# Altium schematic sheet ServoDrivers.SchDoc
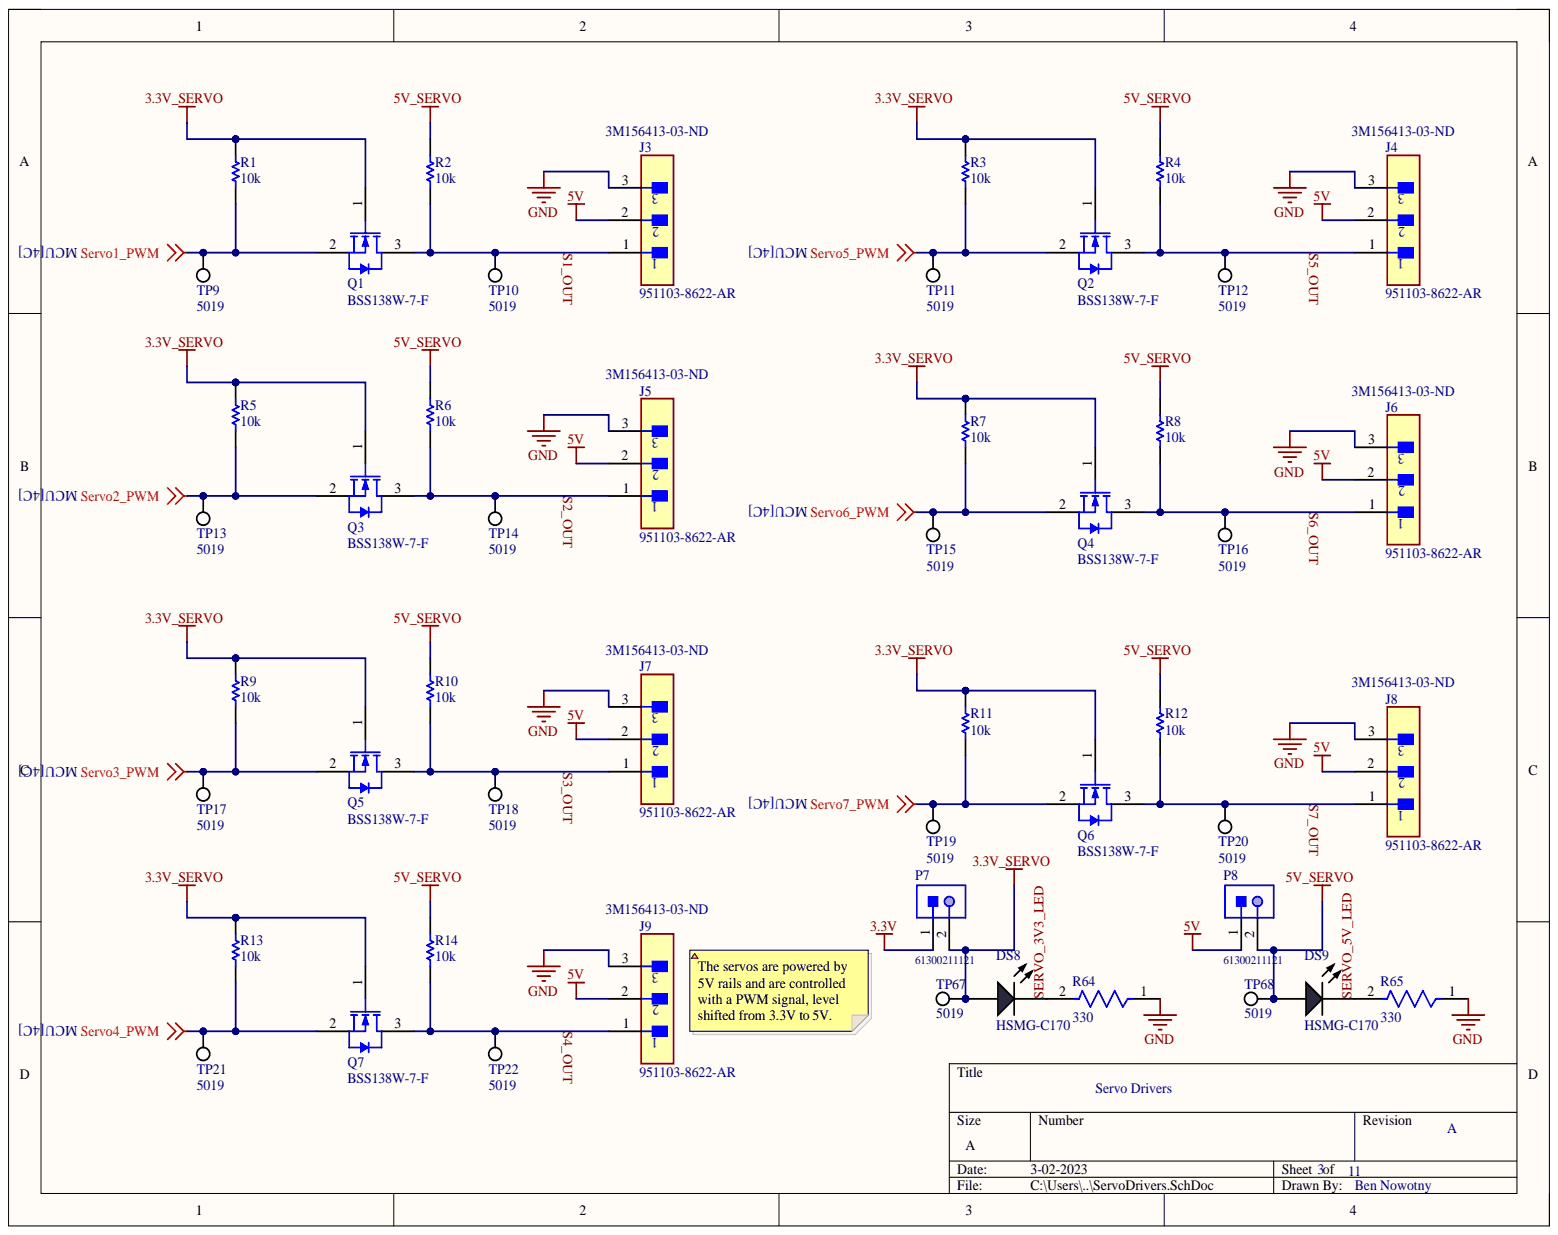
[diagram p0: ServoDrivers.SchDoc]

## Parts
DS8  HSMG-C170  [AVAG-HSMX-C170_V]
DS9  HSMG-C170  [AVAG-HSMX-C170_V]
J3  951103-8622-AR  Supplier Part Number 1: 3M156413-03-ND  [FP-951103-8622-AR-MFG]
J4  951103-8622-AR  Supplier Part Number 1: 3M156413-03-ND  [FP-951103-8622-AR-MFG]
J5  951103-8622-AR  Supplier Part Number 1: 3M156413-03-ND  [FP-951103-8622-AR-MFG]
J6  951103-8622-AR  Supplier Part Number 1: 3M156413-03-ND  [FP-951103-8622-AR-MFG]
J7  951103-8622-AR  Supplier Part Number 1: 3M156413-03-ND  [FP-951103-8622-AR-MFG]
J8  951103-8622-AR  Supplier Part Number 1: 3M156413-03-ND  [FP-951103-8622-AR-MFG]
J9  951103-8622-AR  Supplier Part Number 1: 3M156413-03-ND  [FP-951103-8622-AR-MFG]
P7  61300211121  [61300211121]
P8  61300211121  [61300211121]
Q1  BSS138W-7-F  [DIOD-SOT323-3_V]
Q2  BSS138W-7-F  [DIOD-SOT323-3_V]
Q3  BSS138W-7-F  [DIOD-SOT323-3_V]
Q4  BSS138W-7-F  [DIOD-SOT323-3_V]
Q5  BSS138W-7-F  [DIOD-SOT323-3_V]
Q6  BSS138W-7-F  [DIOD-SOT323-3_V]
Q7  BSS138W-7-F  [DIOD-SOT323-3_V]
R1  10k  [RESC2013X60X35NL10T20]
R2  10k  [RESC2013X60X35NL10T20]
R3  10k  [RESC2013X60X35NL10T20]
R4  10k  [RESC2013X60X35NL10T20]
R5  10k  [RESC2013X60X35NL10T20]
R6  10k  [RESC2013X60X35NL10T20]
R7  10k  [RESC2013X60X35NL10T20]
R8  10k  [RESC2013X60X35NL10T20]
R9  10k  [RESC2013X60X35NL10T20]
R10  10k  [RESC2013X60X35NL10T20]
R11  10k  [RESC2013X60X35NL10T20]
R12  10k  [RESC2013X60X35NL10T20]
R13  10k  [RESC2013X60X35NL10T20]
R14  10k  [RESC2013X60X35NL10T20]
R64  330  [FP-CRCW0805-e3-MFG]
R65  330  [FP-CRCW0805-e3-MFG]
TP9  5019  [KSTN-5019_V]
TP10  5019  [KSTN-5019_V]
TP11  5019  [KSTN-5019_V]
TP12  5019  [KSTN-5019_V]
TP13  5019  [KSTN-5019_V]
TP14  5019  [KSTN-5019_V]
TP15  5019  [KSTN-5019_V]
TP16  5019  [KSTN-5019_V]
TP17  5019  [KSTN-5019_V]
TP18  5019  [KSTN-5019_V]
TP19  5019  [KSTN-5019_V]
TP20  5019  [KSTN-5019_V]
TP21  5019  [KSTN-5019_V]
TP22  5019  [KSTN-5019_V]
TP67  5019  [KSTN-5019_V]
TP68  5019  [KSTN-5019_V]

## Nets
3.3V: P7.1
3.3V_SERVO: DS8.1 P7.2 Q1.1 Q2.1 Q3.1 Q4.1 Q5.1 Q6.1 Q7.1 R1.2 R3.2 R5.2 R7.2 R9.2 R11.2 R13.2 TP67.1
5V: J3.2 J4.2 J5.2 J6.2 J7.2 J8.2 J9.2 P8.1
5V_SERVO: DS9.1 P8.2 R2.2 R4.2 R6.2 R8.2 R10.2 R12.2 R14.2 TP68.1
GND: J3.3 J4.3 J5.3 J6.3 J7.3 J8.3 J9.3 R64.1 R65.1
Servo1_PWM: Q1.2 R1.1 TP9.1
Servo2_PWM: Q3.2 R5.1 TP13.1
Servo3_PWM: Q5.2 R9.1 TP17.1
Servo4_PWM: Q7.2 R13.1 TP21.1
Servo5_PWM: Q2.2 R3.1 TP11.1
Servo6_PWM: Q4.2 R7.1 TP15.1
Servo7_PWM: Q6.2 R11.1 TP19.1
S1_OUT: J3.1 Q1.3 R2.1 TP10.1
S2_OUT: J5.1 Q3.3 R6.1 TP14.1
S3_OUT: J7.1 Q5.3 R10.1 TP18.1
S4_OUT: J9.1 Q7.3 R14.1 TP22.1
S5_OUT: J4.1 Q2.3 R4.1 TP12.1
S6_OUT: J6.1 Q4.3 R8.1 TP16.1
S7_OUT: J8.1 Q6.3 R12.1 TP20.1
SERVO_3V3_LED: DS8.2 R64.2
SERVO_5V_LED: DS9.2 R65.2

## Library data of the parts
DS8 DS9 = CMP-2000-05062-1: Chip LED, Green, 2.2 V, -40 to 85 degC, 2-Pin SMD, Pb-Free, Tape and Reel; Manufacturer: Avago; Bulb Size: 1.25mmx1.4mm; Dominant Wavelength: 572nm; External Depth: 0.8mm; External Length / Height: 2mm; External Width: 1.25mm; Forward Current If: 20mA; Forward Voltage: 2.2V; Forward Voltage VF Max: 2.6V; LED Type: Standard; Lens Shape: Rectangular; Light Area Height: 1mm; Luminous Intensity: 15mcd; Luminous Intensity Min: 4mcd; No. of Pins: 2; Opto Mounting Orientation: Top; Peak Wavelength: 570nm; PIV Max: 5V; SVHC: NoSVHC(16-Jun-2014); Viewing Angle: 170deg; Component Kind: Standard; Datasheet URL: https://datasheet.ciiva.com/29280/180495-da-01-en-chip-led-hsmg-c170-gelb-gruen-29280971.pdf; Datasheet Version: Oct-08; Dominant Wavelength Typ: 572nm; Forward Current If Max: 20mA; LED Colour: Green; LED Mounting: SMD; Library Name: Altium Content Vault; Luminous Intensity Typ: 15mcd; Manufacturer URL: http://www.avagotech.com/; Mounting Type: SMD; MSL: MSL2A-4weeks; Operating Temperature Max: 85degC; Operating Temperature Min: -30degC; Operating Temperature Range: -30degCto+85degC; Opto Case Style: SMD; Package Description: 2-Pin Surface Mount Device, Body 2 x 1.25 mm, Height 0.8 mm; Package Reference: HSMX-C170; Packaging: CutTape; Peak Forward Current: 20mA; Storage Temperature Max: 85degC; Storage Temperature Min: -40degC; Voltage Vf Typ: 2.2V; Wavelength Typ: 570nm
J3-J9 = CMP-05379-000003-1: CONN HEADER VERT 3POS 2MM; Manufacturer: 3M; Conn Gender: Male; Conn Orientation: Straight; Contact Post Length: 2.8mm; Contact Resistance: 20mR; Current Rating: 2A; Max Current Rating: 1A; Number Of Contacts: 3; Voltage Rating: 1kV; Automotive: No; Category: Conn; Color: Black; Component Kind: Standard; ComponentLink1Description: https://datasheet.ciiva.com/730/ts2156-730432.pdf?src-supplier=Digi-Key; ComponentLink1URL: https://datasheet.ciiva.com/730/ts2156-730432.pdf?src-supplier=Digi-Key; ComponentLink2Description: Datasheet; ComponentLink2URL: http://multimedia.3m.com/mws/media/1400506O/3m-pin-strip-header-951-series-78-5100-2653-3.pdf; ComponentLink3Description: https://datasheet.ciiva.com/14622/70237750-14622743.pdf?src-supplier=Allied+Electronics; ComponentLink3URL: https://datasheet.ciiva.com/14622/70237750-14622743.pdf?src-supplier=Allied+Electronics; ComponentLink4Description: https://datasheet.ciiva.com/7997/ts2156-7997244.pdf?src-supplier=Element14; ComponentLink4URL: https://datasheet.ciiva.com/7997/ts2156-7997244.pdf?src-supplier=Element14; Connector Type: Header; Contact Finish - Mating: GOLD; Contact Gender: Male; Contact Material: Gold,Nickel; Contact Mating Length: 0.173inch; Contact Plating: Gold; Contact Shape: Square; Contact Type: Male Pin; Depth: 2mm; Device Class L1: Connectors; Device Class L2: Headers and Wire Housings; Device Class L3: unset; Digikey Description: CONN HEADER VERT 3POS 2MM; Fastening Type: Pull; Flammability Rating: UL94 V-0; Gender: Male; Height: 6.3mm; Housing Color: Black; Housing Material: Thermoplastic; Insulation Color: Black; Insulation Material: Thermoplastic; Lead Free: Yes; Length: 6mm; Library Name: Altium Content Vault; Manufacturer Part Number: 951103-8622-AR; Max Operating Temperature: 125°C; Min Operating Temperature: -55°C; Mounting Technology: Through Hole; Mouser Description: Header; PinStrip; CopperAlloy; HighTempThermoplastic; 3Cnts; 1A; RtAngle; 951Series; Number of Positions: 3; Number Of Rows: 1; Octopart: https://octopart.com/951103-8622-ar-3m-14400669; Orientation: Straight; Package: 951103-8622-AR; Package Quantity: 3000; Packaging: Bulk; Pitch: 2mm; Pricing 1: 1=0.30000, 10=0.28800, 25=0.24720, 50=0.21020, 100=0.20190, 250=0.18128, 500=0.17304 (USD); RoHS: TRUE; RoHS Compliant: Yes; Series: 951; Stock 1: 1012; Supplier 1: Digi-Key; Temperature: -55°C to +125°C; Temperature Range High: +125°C; Temperature Range Low: -55°C; Termination: Solder
P7 P8 = CMP-1712-00002-4: THT Vertical Pin Header WR-PHD, Pitch 2.54 mm, Single Row, 2 pins; Manufacturer: Wurth Elektronik; Connector Type: Male Pin; Contact Resistance: 20mOhm; Current Rating: 3A; Gender: Male; Number of Contacts: 2; Pitch: 2.54mm; Voltage Rating: 250V; Withstanding Voltage: 500VAC; Working Voltage: 250VAC; Category: Headers and Wire Housings; Color: Black; Component Kind: Standard; ComponentLink1Description: https://datasheet.ciiva.com/13619/6130xx11121-48870-13619345.pdf?src-supplier=Mouser; ComponentLink1URL: https://datasheet.ciiva.com/13619/6130xx11121-48870-13619345.pdf?src-supplier=Mouser; Contact Gender: Male; Contact Material: Copper Alloy,Copper; Contact Mating Length: 0.236inch; Contact Plating: Gold; Contact Type: Male Pin; Datasheet URL: https://katalog.we-online.de/em/datasheet/6130xx11121.pdf; Housing Material: PBT; Lead Free: Lead Free; Library Name: Altium Content Vault; Manufacturer Part Number: 61300211121; Manufacturer URL: http://www.we-online.de; Max Operating Temperature: 125°C; Min Operating Temperature: -40°C; Mounting Technology: THT; Number of Positions: 2; Number of Rows: 1; Operating Temperature: -40to105degC; Orientation: Vertical; Package Reference: 61300211121; Packaging: Bag; Part Number: 61300211121; Pin Number: 2; Pricing 1: 1=0.13000, 10=0.11300, 25=0.09400, 50=0.07900, 100=0.07100, 1000=0.06500 (USD); Rated Current: 3A; RoHS: Yes; RoHS Compliant: Yes; Row Number: Single; Series: WR-PHD; Stock 1: 204500; Supplier 1: Digi-Key; Supplier Part Number 1: 732-5315-ND; Termination: Through Hole; Publisher: WurthElektronik
Q1-Q7 = CMP-2000-05968-1: N-Channel Enhancement Mode Mosfet, 50 V, 200 mA, -55 to 150 degC, 6-Pin SOT-323, RoHS, Tape and Reel; Brand: DiodesIncorporated; Configuration: Single; Continuous Drain Current (ID): 200mA; Drain to Source Breakdown Voltage: 75V; Drain to Source Resistance: 1.4R; Drain to Source Voltage (Vdss): 50V; Drain-source On Resistance-Max: 3.5R; Forward Transconductance - Min: 0.1S; Gate to Source Voltage (Vgs): 20V; Input Capacitance: 50pF; Max Power Dissipation: 200mW; Nominal Vgs: 1.2V; Number of Channels: 1Channel; Number of Elements: 1; Rds On - Drain-Source Resistance: 1.4Ohms; Transistor Type: 1N-Channel; Turn-Off Delay Time: 20ns; Type: EnhancementModeFieldEffectTransistor; Unit Weight: 0.000212oz; Case/Package: SOT-323; Category: MOSFETs; Channel Mode: Enhancement; Component Kind: Standard; Contact Plating: Tin,Matte; Current Rating: 200mA; Datasheet URL: http://www.diodes.com/_files/datasheets/ds30206.pdf; Datasheet Version: Rev. 11-2, 09/2013; Dual Supply Voltage: 50V; Element Configuration: Single; Factory Pack Quantity: 3000; Height: 1mm; Id - Continuous Drain Current: 200mA; Lead Free: Lead Free; Length: 2.2mm; Library Name: Altium Content Vault; Manufacturer: DiodesIncorporated; Manufacturer Part Number: BSS138W-7-F; Manufacturer URL: http://www.diodes.com/; Max Operating Temperature: 150°C; Maximum Operating Temperature: +150C; Min Operating Temperature: -55°C; Minimum Operating Temperature: -55C; Mounting Style: SMD/SMT; Mounting Technology: SurfaceMount; On-State Resistance: 3.5R; Package / Case: SOT-323-3; Package Description: 3-Pin Small Outline Transistor, Body 2 x 1.25 mm, Pitch 0.65 mm; Package Quantity: 1; Package Reference: SOT323; Packaging: Reel; Pd - Power Dissipation: 200mW; Pins: 3; Polarity: N-CHANNEL; Power Dissipation: 200mW; Pricing 1: 1=0.31000, 10=0.25600, 100=0.13590, 500=0.08938, 1000=0.06078 (USD); Product: MOSFETSmallSignal; Product Category: MOSFET; Rds On Max: 3.5R; Reach SVHC Compliant: Yes; RoHS: True; RoHS Compliant: Yes; Series: BSS138; Stock 1: 346188; Supplier 1: Digi-Key; Supplier Part Number 1: BSS138W-FDICT-ND; Technology: Si; Termination: SMD/SMT; Threshold Voltage: 1.2V; Transistor Polarity: N-Channel; Turn-On Delay Time: 20ns; Typical Turn-Off Delay Time: 20ns; Typical Turn-On Delay Time: 20ns; Vds - Drain-Source Breakdown Voltage: 75V; Vgs - Gate-Source Voltage: 20V; Voltage: 50V; Voltage Rating (DC): 50V; Weight: 0.000212oz; Width: 1.35mm
R1-R14 = CMP-1659-00013-4: Chip Resistor, 10 KOhm, +/- 1%, 0.125 W, -55 to 155 degC, 0805 (2012 Metric), RoHS, Tape and Reel; Manufacturer: Yageo; Manufacturer Part Number: RC0805FR-0710KL; Max Power Dissipation: 125mW; Temperature Coefficient: 100ppm/°C; Value: 10kR; Voltage Rating: 150 V; Case Code (Imperial): 0805; Case Code (Metric): 2012; Case/Package: 0805; Category: Chip SMD Resistors; Component Kind: Standard; ComponentLink1Description: Manufacturer URL; ComponentLink1URL: http://www.yageo.com/; ComponentLink2Description: Datasheet; ComponentLink2URL: http://www.yageo.com/documents/recent/PYu-RC_Group_51_RoHS_L_04.pdf; Construction: Rectangular; DatasheetVersion: Rev. 4, 02/2016; Depth: 1.25mm; Features: Moisture Resistant; Height: 0.5 mm; Lead Free: Lead Free; Length: 2 mm; Library Name: Altium Content Vault; Max Operating Temperature: 155 degC; Military Standard: Not; Min Operating Temperature: -55 degC; Mount: Surface Mount; Mounting Technology: Surface Mount; Number of Terminations: 2; Package Quantity: 5000; PackageDescription: 2-Pin Surface Mounted Device, Body 2 x 1.25 mm; PackageReference: 0805; Packaging: Tape and Reel; Pins: 2; Power Rating: 125mW; Pricing 1: 1=0.10000, 10=0.03300, 100=0.01340, 1000=0.00602, 2500=0.00523 (USD); REACH SVHC: No SVHC; Resistance Tolerance: 1%; Resistor Type: Thick Film; RoHS: Yes; RoHS Compliant: Yes; RoHSCompliant: true; Series: RC; Stock 1: 11227289; Supplier 1: Digi-Key; Supplier Part Number 1: 311-10.0KCRCT-ND; Technology: THICK FILM; Termination: SMD/SMT; Tolerance: 1%; Width: 0.049inch
R64 R65 = CMP-2001-03823-2: RES Thick Film, 330Ω, 1%, 0.125W, 100ppm/°C, 0805; Manufacturer: Vishay; Manufacturer Part Number: CRCW0805330RFKEA; Max Power Dissipation: 125mW; Resistance: 330R; Voltage Rating: 150V; Case Code (Imperial): 0805; Case Code (Metric): 2012; Case/Package: 0805; Category: Chip SMD Resistors; Component Kind: Standard; ComponentLink1Description: https://datasheet.ciiva.com/8944/dcrcwe3-8944773.pdf?src-supplier=Avnet; ComponentLink1URL: https://datasheet.ciiva.com/8944/dcrcwe3-8944773.pdf?src-supplier=Avnet; ComponentLink2Description: https://datasheet.ciiva.com/8944/dcrcwe3-8944776.pdf?src-supplier=Avnet; ComponentLink2URL: https://datasheet.ciiva.com/8944/dcrcwe3-8944776.pdf?src-supplier=Avnet; ComponentLink3Description: https://datasheet.ciiva.com/2495/dcrcwe3-2495803.pdf?src-supplier=Digi-Key; ComponentLink3URL: https://datasheet.ciiva.com/2495/dcrcwe3-2495803.pdf?src-supplier=Digi-Key; ComponentLink4Description: https://datasheet.ciiva.com/2495/dcrcwe3-2495804.pdf?src-supplier=Digi-Key; ComponentLink4URL: https://datasheet.ciiva.com/2495/dcrcwe3-2495804.pdf?src-supplier=Digi-Key; ComponentLink5Description: https://datasheet.ciiva.com/2495/dcrcwe3-2495805.pdf?src-supplier=Digi-Key; ComponentLink5URL: https://datasheet.ciiva.com/2495/dcrcwe3-2495805.pdf?src-supplier=Digi-Key; ComponentLink6Description: https://datasheet.ciiva.com/5545/dcrcwe3-109170-5545357.pdf?src-supplier=Mouser; ComponentLink6URL: https://datasheet.ciiva.com/5545/dcrcwe3-109170-5545357.pdf?src-supplier=Mouser; ComponentLink7Description: https://datasheet.ciiva.com/16769/70201119-16769852.pdf?src-supplier=Allied+Electronics; ComponentLink7URL: https://datasheet.ciiva.com/16769/70201119-16769852.pdf?src-supplier=Allied+Electronics; ComponentLink8Description: https://datasheet.ciiva.com/7777/1697337-7777390.pdf?src-supplier=Element14; ComponentLink8URL: https://datasheet.ciiva.com/7777/1697337-7777390.pdf?src-supplier=Element14; ComponentLink9Description: https://datasheet.ciiva.com/7777/1720486-7777573.pdf?src-supplier=Element14; ComponentLink9URL: https://datasheet.ciiva.com/7777/1720486-7777573.pdf?src-supplier=Element14; Composition: Thick Film; Construction: Rectangular; Contact Plating: Tin; Depth: 1.25mm; Features: Automotive AEC-Q200; Height: 0.02inch; Lead Free: Lead Free; Length: 2mm; Library Name: Altium Content Vault; Max Operating Temperature: 155°C; Military Standard: Not; Min Operating Temperature: -55°C; Mount: Surface Mount; Number of Terminations: 2; Package Quantity: 10; Packaging: Tape and Reel; Power Rating: 125mW; Pricing 1: 1=0.10000, 10=0.06700, 100=0.02710, 1000=0.01219, 2500=0.01058 (USD); Ratings: AEC-Q200; Resistance Tolerance: 1%; Resistor Type: Thick Film; RoHS: Yes; RoHS Compliant: Yes; Series: CRCW; Size Code: 0805; Stock 1: 1889; Supplier 1: Digi-Key; Supplier Part Number 1: 541-330CCT-ND; Temperature Coefficient: 100ppm/°C; Termination: SMD/SMT; Tolerance: 1%; Voltage Rating (DC): 150V; Weight: 0.000194oz; Width: 0.049inch
TP9-TP22 TP67 TP68 = CMP-1672-00008-1: Test Point, 1 Position SMD, RoHS, Tape and Reel; Manufacturer: Keystone Electronics; Body Material: Bronze; Plating: Silver; Category: Connectors; Component Kind: Standard; ComponentLink1Description: Manufacturer URL; ComponentLink1URL: http://www.keyelco.com/; ComponentLink2Description: Datasheet; ComponentLink2URL: http://www.keyelco.com/product-pdf.cfm?p=1357; ComponentLink3Description: https://datasheet.ciiva.com/16885/70182998-16885945.pdf?src-supplier=Allied+Electronics; ComponentLink3URL: https://datasheet.ciiva.com/16885/70182998-16885945.pdf?src-supplier=Allied+Electronics; ComponentLink4Description: https://datasheet.ciiva.com/14848/m60-2p61-14848437.pdf?src-supplier=Digi-Key; ComponentLink4URL: https://datasheet.ciiva.com/14848/m60-2p61-14848437.pdf?src-supplier=Digi-Key; ComponentLink5Description: https://datasheet.ciiva.com/14848/m60-2p61-14848438.pdf?src-supplier=Digi-Key; ComponentLink5URL: https://datasheet.ciiva.com/14848/m60-2p61-14848438.pdf?src-supplier=Digi-Key; ComponentLink6Description: https://datasheet.ciiva.com/14848/m60-2p61-14848439.pdf?src-supplier=Digi-Key; ComponentLink6URL: https://datasheet.ciiva.com/14848/m60-2p61-14848439.pdf?src-supplier=Digi-Key; ComponentLink7Description: https://datasheet.ciiva.com/16318/m60-2p61-16318395.pdf?src-supplier=Future+Electronics; ComponentLink7URL: https://datasheet.ciiva.com/16318/m60-2p61-16318395.pdf?src-supplier=Future+Electronics; Contact Material: Bronze; Contact Plating: Silver; DatasheetVersion: 03/2014; Height: 0.09inch; Lead Free: Lead Free; Library Name: Altium Content Vault; Manufacturer Part Number: 5019; Material: Bronze; Mount: Surface Mount; Mounting Technology: Surface Mount; Number of Pins: 1; Package Quantity: 1000; PackageDescription: 1-Pin Surface Mount Device, Body 3.81 x 2.03 mm; PackageReference: 5019; Packaging: Tape and Reel; Pins: 1; Pricing 1: 1=0.34000, 25=0.27360, 100=0.22340, 250=0.20064, 500=0.19152 (USD); Reach SVHC Compliant: Yes; RoHS: Yes; RoHS Compliant: Yes; RoHSCompliant: True; Series: 5019; Stock 1: 1009631; Supplier 1: Digi-Key; Supplier Part Number 1: 36-5019CT-ND; Termination: SMD/SMT
